# Altium schematic sheet FPGA_BD.SchDoc
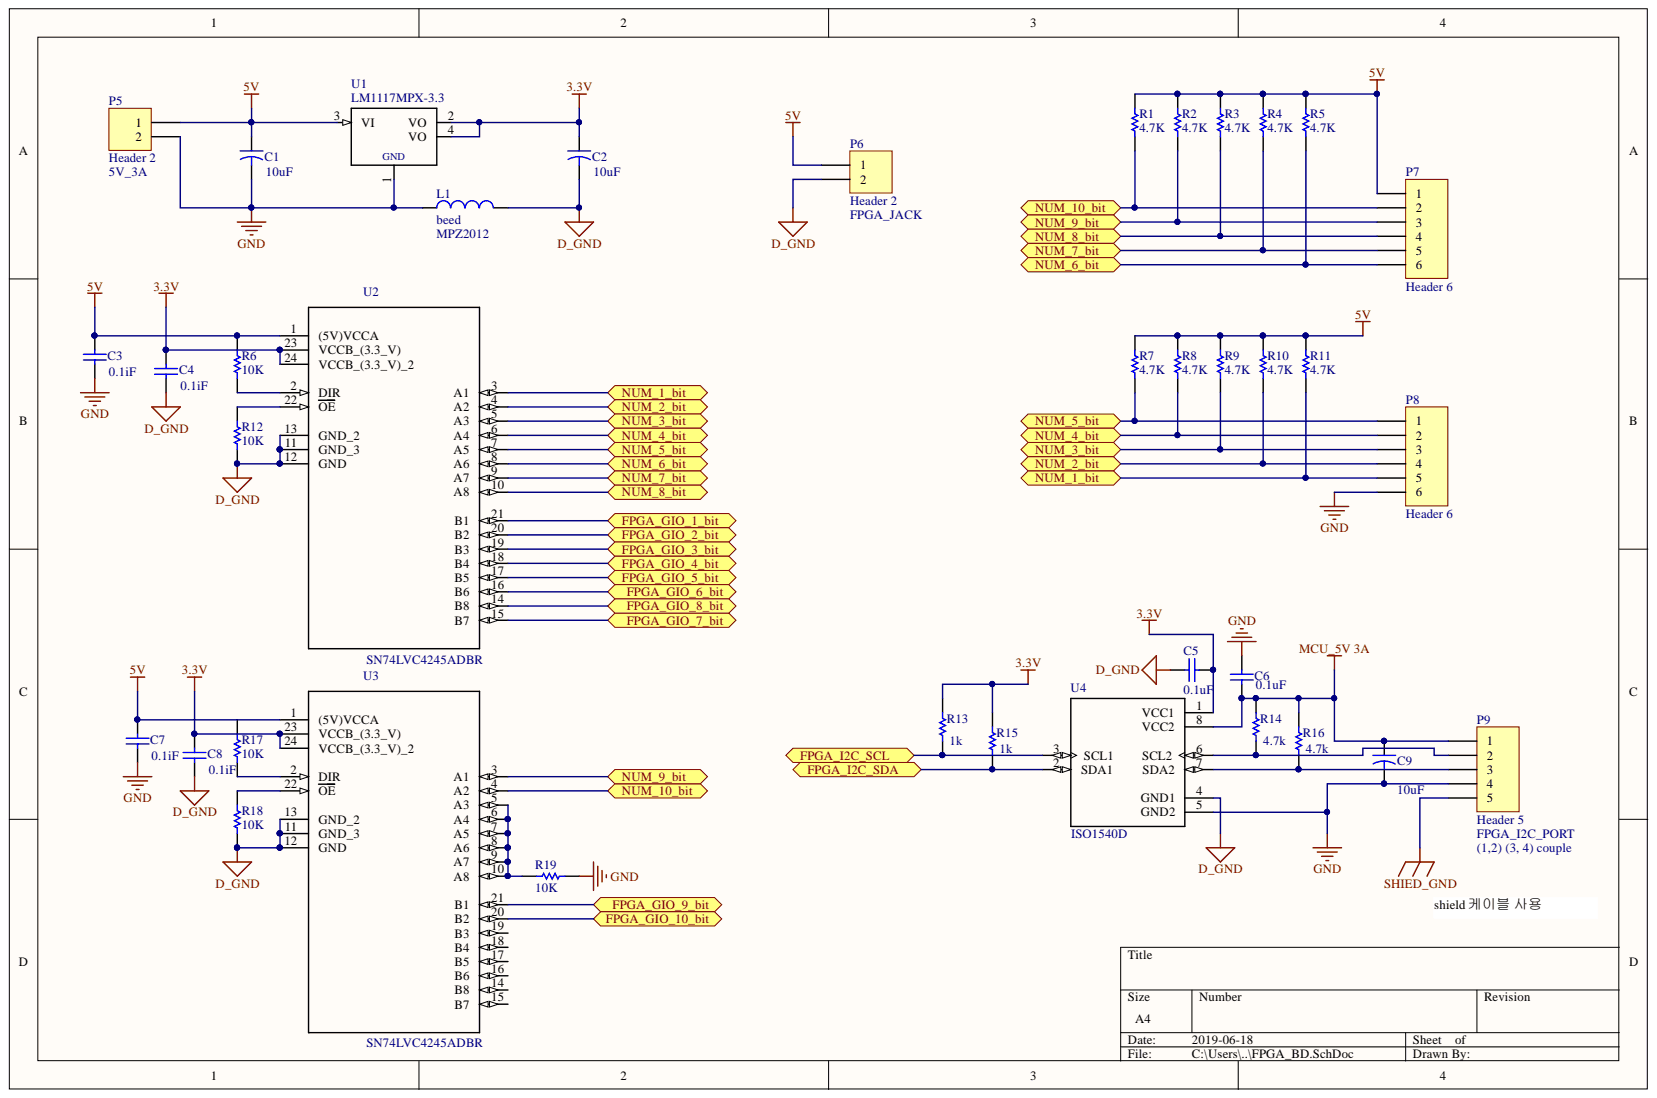
[diagram p0: FPGA_BD.SchDoc]

## Parts
C1  value 10uF  [CAPR5-4X5]  (hidden comment: Cap2)
C2  value 10uF  [CAPR5-4X5]  (hidden comment: Cap2)
C3  value 0.1iF  [RAD-0.3]  (hidden comment: Cap)
C4  value 0.1iF  [RAD-0.3]  (hidden comment: Cap)
C5  value 0.1uF  [RAD-0.3]  (hidden comment: Cap)
C6  value 0.1uF  [RAD-0.3]  (hidden comment: Cap)
C7  value 0.1iF  [RAD-0.3]  (hidden comment: Cap)
C8  value 0.1iF  [RAD-0.3]  (hidden comment: Cap)
C9  value 10uF  [CAPR5-4X5]  (hidden comment: Cap2)
L1  beed  value MPZ2012  [0402-A]
P5  Header 2  [HDR1X2]
P6  Header 2  [HDR1X2]
P7  Header 6  [HDR1X6]
P8  Header 6  [HDR1X6]
P9  Header 5  [HDR1X5]
R1  value 4.7K  [AXIAL-0.3]  (hidden comment: Res1)
R2  value 4.7K  [AXIAL-0.3]  (hidden comment: Res1)
R3  value 4.7K  [AXIAL-0.3]  (hidden comment: Res1)
R4  value 4.7K  [AXIAL-0.3]  (hidden comment: Res1)
R5  value 4.7K  [AXIAL-0.3]  (hidden comment: Res1)
R6  value 10K  [AXIAL-0.3]  (hidden comment: Res1)
R7  value 4.7K  [AXIAL-0.3]  (hidden comment: Res1)
R8  value 4.7K  [AXIAL-0.3]  (hidden comment: Res1)
R9  value 4.7K  [AXIAL-0.3]  (hidden comment: Res1)
R10  value 4.7K  [AXIAL-0.3]  (hidden comment: Res1)
R11  value 4.7K  [AXIAL-0.3]  (hidden comment: Res1)
R12  value 10K  [AXIAL-0.3]  (hidden comment: Res1)
R13  value 1k  [AXIAL-0.3]  (hidden comment: Res1)
R14  value 4.7k  [AXIAL-0.3]  (hidden comment: Res1)
R15  value 1k  [AXIAL-0.3]  (hidden comment: Res1)
R16  value 4.7k  [AXIAL-0.3]  (hidden comment: Res1)
R17  value 10K  [AXIAL-0.3]  (hidden comment: Res1)
R18  value 10K  [AXIAL-0.3]  (hidden comment: Res1)
R19  value 10K  [AXIAL-0.3]  (hidden comment: Res1)
U1  LM1117MPX-3.3  [*SOT230P700X180-4N]
U2  SN74LVC4245ADBR  [*SOP65P780X200-24N]
U3  SN74LVC4245ADBR  [*SOP65P780X200-24N]
U4  ISO1540D  [*SOIC127P600X175-8N]

## Ports
FPGA_GIO_1_BIT, FPGA_GIO_2_BIT, FPGA_GIO_3_BIT, FPGA_GIO_4_BIT, FPGA_GIO_5_BIT, FPGA_GIO_6_BIT, FPGA_GIO_7_BIT, FPGA_GIO_8_BIT, FPGA_GIO_9_BIT, FPGA_GIO_10_BIT, FPGA_I2C_SCL, FPGA_I2C_SDA, NUM_1_BIT, NUM_2_BIT, NUM_3_BIT, NUM_4_BIT, NUM_5_BIT, NUM_6_BIT, NUM_7_BIT, NUM_8_BIT, NUM_9_BIT, NUM_10_BIT

## Nets
3.3V: C2.1 C4.1 C5.1 C8.1 R13.2 R15.2 U1.2(VO) U1.4(VO) U2.23(VCCB_(3.3_V)) U2.24(VCCB_(3.3_V)_2) U3.23(VCCB_(3.3_V)) U3.24(VCCB_(3.3_V)_2) U4.1(VCC1)
5V: C1.1 C3.1 C7.1 P5.1 P6.1 P7.1 R1.2 R2.2 R3.2 R4.2 R5.2 R6.2 R7.2 R8.2 R9.2 R10.2 R11.2 U1.3(VI) U2.1((5V)VCCA) U3.1((5V)VCCA)
D_GND: C2.2 C4.2 C5.2 C8.2 L1.2 P6.2 R12.1 R18.1 U2.11(GND_3) U2.12(GND) U2.13(GND_2) U3.11(GND_3) U3.12(GND) U3.13(GND_2) U4.4(GND1)
GND: C1.2 C3.2 C6.2 C7.2 C9.2 L1.1 P5.2 P8.6 P9.4 R19.1 U1.1 U4.5(GND2)
MCU_5V 3A: C6.1 C9.1 P9.1 R14.2 R16.2 U4.8(VCC2)
SHIED_GND: P9.5
FPGA_I2C_SCL: R13.1 U4.3(SCL1) ; port FPGA_I2C_SCL
FPGA_I2C_SDA: R15.1 U4.2(SDA1) ; port FPGA_I2C_SDA
NetP7_2: P7.2 R1.1 U3.4(A2) ; port NUM_10_BIT
NetP7_3: P7.3 R2.1 U3.3(A1) ; port NUM_9_BIT
NetP7_4: P7.4 R3.1 U2.10(A8) ; port NUM_8_BIT
NetP7_5: P7.5 R4.1 U2.9(A7) ; port NUM_7_BIT
NetP7_6: P7.6 R5.1 U2.8(A6) ; port NUM_6_BIT
NetP8_1: P8.1 R7.1 U2.7(A5) ; port NUM_5_BIT
NetP8_2: P8.2 R8.1 U2.6(A4) ; port NUM_4_BIT
NetP8_3: P8.3 R9.1 U2.5(A3) ; port NUM_3_BIT
NetP8_4: P8.4 R10.1 U2.4(A2) ; port NUM_2_BIT
NetP8_5: P8.5 R11.1 U2.3(A1) ; port NUM_1_BIT
NetP9_2: P9.2 R14.1 U4.6(SCL2)
NetP9_3: P9.3 R16.1 U4.7(SDA2)
NetR6_1: R6.1 U2.2(DIR)
NetR12_2: R12.2 U2.22(OE)
NetR17_1: R17.1 U3.2(DIR)
NetR18_2: R18.2 U3.22(OE)
NetR19_2: R19.2 U3.5(A3) U3.6(A4) U3.7(A5) U3.8(A6) U3.9(A7) U3.10(A8)
NetU2_14: U2.14(B8) ; port FPGA_GIO_8_BIT
NetU2_15: U2.15(B7) ; port FPGA_GIO_7_BIT
NetU2_16: U2.16(B6) ; port FPGA_GIO_6_BIT
NetU2_17: U2.17(B5) ; port FPGA_GIO_5_BIT
NetU2_18: U2.18(B4) ; port FPGA_GIO_4_BIT
NetU2_19: U2.19(B3) ; port FPGA_GIO_3_BIT
NetU2_20: U2.20(B2) ; port FPGA_GIO_2_BIT
NetU2_21: U2.21(B1) ; port FPGA_GIO_1_BIT
NetU3_20: U3.20(B2) ; port FPGA_GIO_10_BIT
NetU3_21: U3.21(B1) ; port FPGA_GIO_9_BIT

Not connected: R17 2; U3 14(B8) 15(B7) 16(B6) 17(B5) 18(B4) 19(B3)

## Library data of the parts
C1 C2 C9 = Cap2: Capacitor; Component Kind: Standard; Library Name: Miscellaneous Devices.IntLib; PackageDescription: Capacitor; 2 Leads; PackageReference: CAPR5-4X5; Published: 23-Sep-2002; Signal Integrity: Cap; Simulation: CAP; Publisher: Altium Limited
C3-C8 = Cap: Capacitor; Component Kind: Standard; LatestRevisionDate: 17-Jul-2002; LatestRevisionNote: Re-released for DXP Platform.; Library Name: Miscellaneous Devices.IntLib; PackageDescription: Radial Cap, Thru-Hole; 2 Leads; 0.3 in Pin Spacing; PackageReference: RAD-0.3; Published: 8-Jun-2000; Signal Integrity: Cap; Simulation: CAP; Publisher: Altium Limited
L1 = Inductor: Code_IEC: 1005; Code_JEITA: 0402; Component Kind: Standard; Description: Inductor; LatestRevisionDate: 29-May-2009; LatestRevisionNote: IPC-7351 Footprint Added.; Library Name: Miscellaneous Devices.IntLib; PackageDescription: Chip Inductor; PackageReference: 0402-A; Published: 8-Jun-2000; Signal Integrity: Inductor; Simulation: INDUCTOR; Publisher: Altium Limited
P5 P6 = Header 2: Header, 2-Pin; Component Kind: Standard; LatestRevisionDate: 17-Jul-2002; LatestRevisionNote: Re-released for DXP Platform.; Library Name: Miscellaneous Connectors.IntLib; Signal Integrity: Connector; Publisher: Altium Limited
P7 P8 = Header 6: Header, 6-Pin; Component Kind: Standard; LatestRevisionDate: 17-Jul-2002; LatestRevisionNote: Re-released for DXP Platform.; Library Name: Miscellaneous Connectors.IntLib; Signal Integrity: Connector; Publisher: Altium Limited
P9 = Header 5: Header, 5-Pin; Component Kind: Standard; LatestRevisionDate: 17-Jul-2002; LatestRevisionNote: Re-released for DXP Platform.; Library Name: Miscellaneous Connectors.IntLib; Signal Integrity: Connector; Publisher: Altium Limited
R1-R19 = Res1: Resistor; Component Kind: Standard; LatestRevisionDate: 17-Jul-2002; LatestRevisionNote: Re-released for DXP Platform.; Library Name: Miscellaneous Devices.IntLib; PackageDescription: Axial Device, Thru-Hole; 2 Leads; 0.3 in Pin Spacing; PackageReference: AXIAL-0.3; Published: 8-Jun-2000; Signal Integrity: Res; Simulation: RESISTOR; Publisher: Altium Limited
U1 = LM1117MPX-3.3: 4 pin 800mA Low-Dropout Linear Regulator 2000 per reel; MF: Texas Instruments; Availability: Unavailable; Component Kind: Standard; Library Name: LM1117MPX-3.3.IntLib; MP: LM1117MPX-3.3; Package: SOT-223 Texas Instruments; Price: None; SnapEDA_Link: https://www.snapeda.com/parts/LM1117MPX-3.3/Texas%20Instruments/view-part/?ref=snap
U2 U3 = SN74LVC4245ADBR: Octal Bus Transceiver And 3.3-V To 5-V Shifter With 3-State Outputs 24-SSOP -40 to 85; MF: Texas Instruments; Availability: Unavailable; Component Kind: Standard; Library Name: SN74LVC4245ADBR.IntLib; MP: SN74LVC4245ADBR; Package: SSOP-24 Texas Instruments; Price: None; SnapEDA_Link: https://www.snapeda.com/parts/SN74LVC4245ADBR/Texas%20Instruments/view-part/?ref=snap
U4 = ISO1540D: 2.5 kVrms Isolated Bidirectional Clock, Bidirectional I2C Isolators 8-SOIC -40 to 125; MF: Texas Instruments; Availability: Unavailable; Component Kind: Standard; Library Name: ISO1540D.SchLib; MP: ISO1540D; Package: SOIC-8 Texas Instruments; Price: None; SnapEDA_Link: https://www.snapeda.com/parts/ISO1540D/Texas%20Instruments/view-part/?ref=snap
